# Altium schematic sheet TOP.SchDoc(TOP)
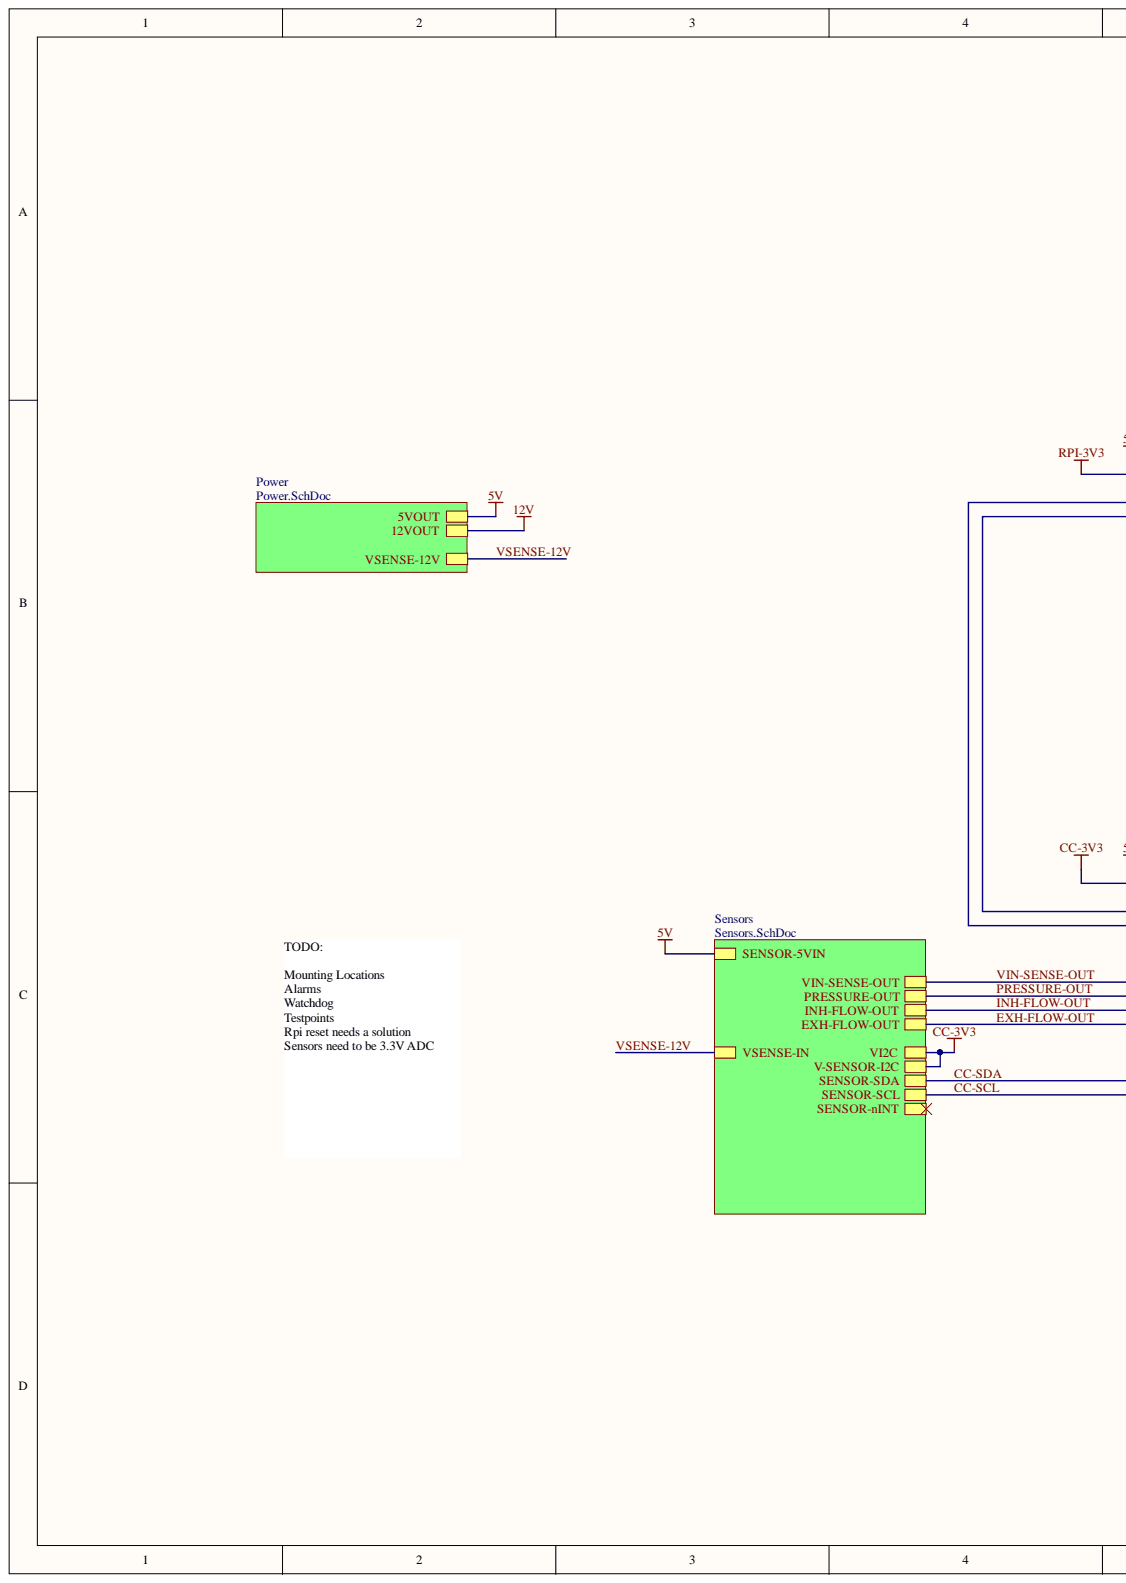
[diagram p0: TOP.SchDoc, left]
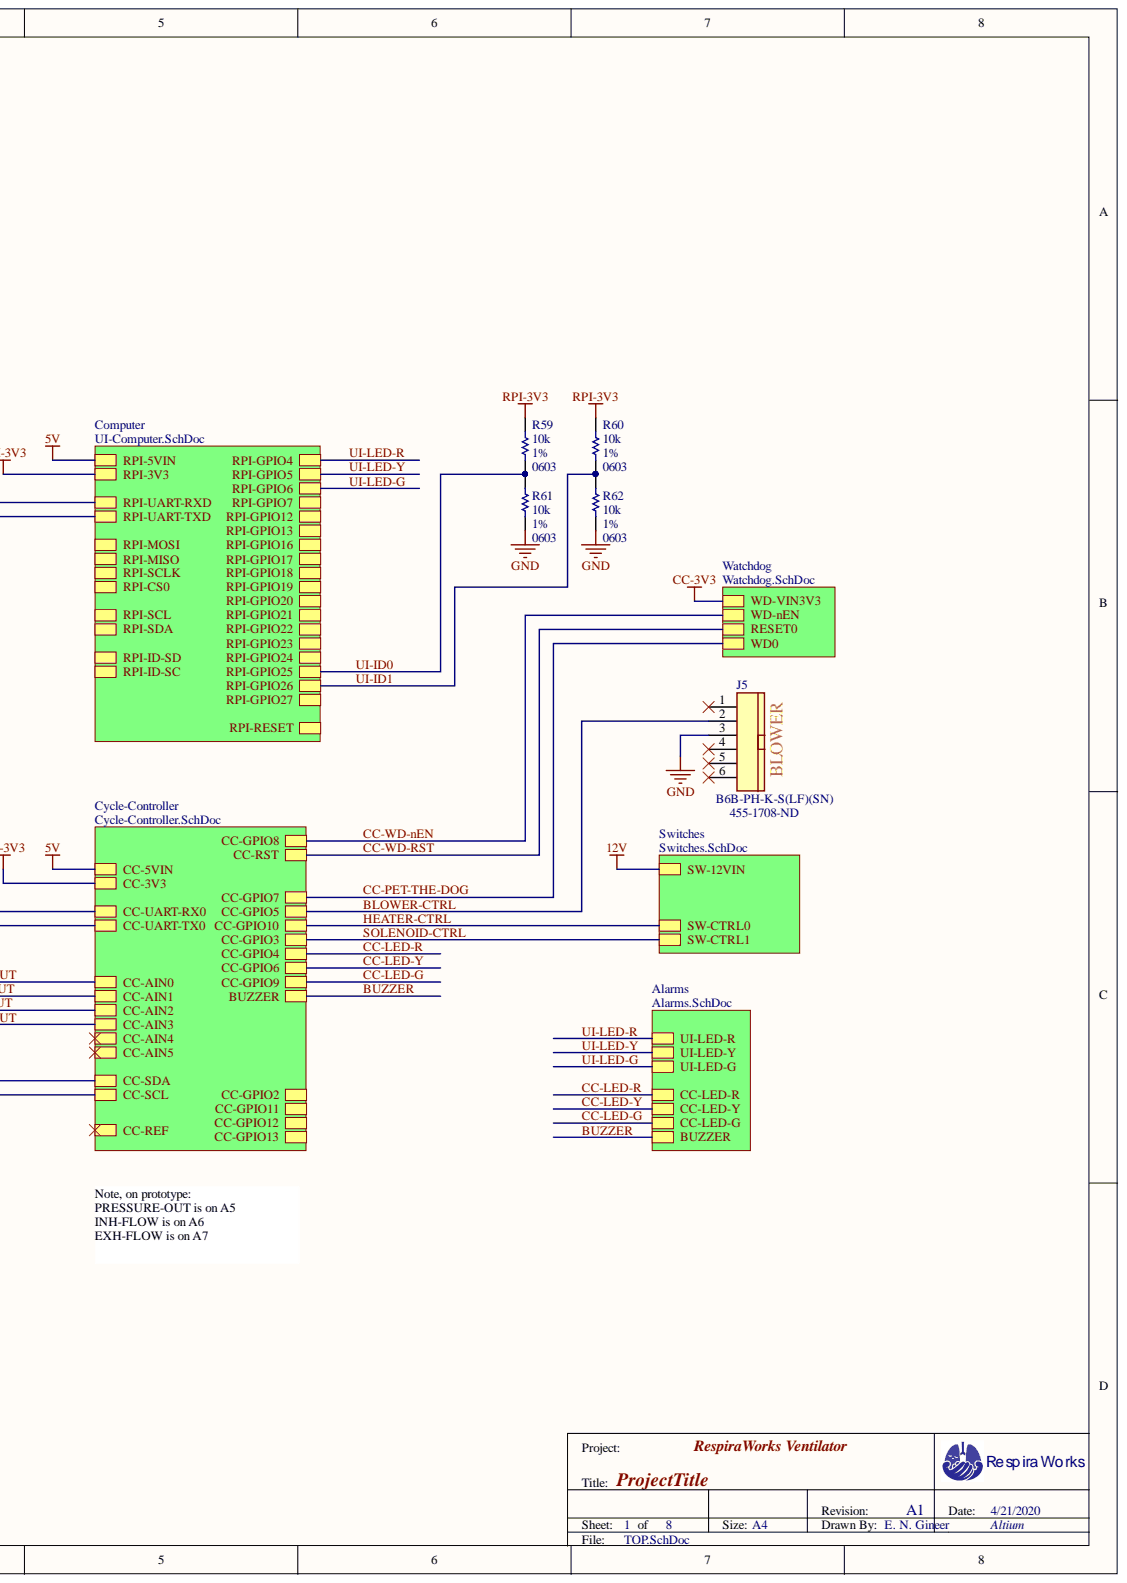
[diagram p1: TOP.SchDoc, right]

## Parts
J5  B6B-PH-K-S(LF)(SN)  Supplier Part Number 1: 455-1708-ND  [JST_B6B-XH-AM]
R59  value 10k  Tolerance: 1%  PCB Package: 0603  [RES0603]  (hidden comment: RES 0603)
R60  value 10k  Tolerance: 1%  PCB Package: 0603  [RES0603]  (hidden comment: RES 0603)
R61  value 10k  Tolerance: 1%  PCB Package: 0603  [RES0603]  (hidden comment: RES 0603)
R62  value 10k  Tolerance: 1%  PCB Package: 0603  [RES0603]  (hidden comment: RES 0603)

## Nets
GND: J5.3 R61.1 R62.1
RPI-3V3: R59.2 R60.2
BLOWER-CTRL: J5.2
UI-ID0: R59.1 R61.2
UI-ID1: R60.1 R62.2
NetJ5_1: J5.1
NetJ5_4: J5.4
NetJ5_5: J5.5
NetJ5_6: J5.6
5V:
12V:
BUZZER:
CC-3V3:
CC-AIN4:
CC-AIN5:
CC-GPIO2:
CC-GPIO11:
CC-GPIO12:
CC-GPIO13:
CC-LED-G:
CC-LED-R:
CC-LED-Y:
CC-PET-THE-DOG:
CC-REF:
CC-SCL:
CC-SDA:
CC-WD-nEN:
CC-WD-RST:
EXH-FLOW-OUT:
HEATER-CTRL:
INH-FLOW-OUT:
PRESSURE-OUT:
RPI-CS0:
RPI-GPIO7:
RPI-GPIO12:
RPI-GPIO13:
RPI-GPIO16:
RPI-GPIO17:
RPI-GPIO18:
RPI-GPIO19:
RPI-GPIO20:
RPI-GPIO21:
RPI-GPIO22:
RPI-GPIO23:
RPI-GPIO24:
RPI-GPIO27:
RPI-ID-SC:
RPI-ID-SD:
RPI-MISO:
RPI-MOSI:
RPI-RESET:
RPI-SCL:
RPI-SCLK:
RPI-SDA:
RPI-UART-RXD:
RPI-UART-TXD:
SENSOR-nINT:
SOLENOID-CTRL:
UI-LED-G:
UI-LED-R:
UI-LED-Y:
VIN-SENSE-OUT:
VSENSE-12V:

## Library data of the parts
J5 = B6B-PH-K-S(LF)(SN): CONN HEADER PH TOP 6POS 2MM; Manufacturer: JST Sales America Inc.; Pitch: 0.079" (2.00mm); Number of Positions Loaded: All; Number of Positions: 6; Connector Type: Header, Shrouded; Termination: Press-Fit, Solder; Supplier 1: Digi-Key; Stock 1: 30111; Row Spacing: -; RoHS: RoHS Compliant; Pricing 1: 1=0.33, 10=0.313, 100=0.2194, 500=0.18808, 1000=0.15674, 5000=0.13435, 10000=0.12539 (USD); Part Status: Active; Packaging: Bulk; Number of Rows: 1; Mounting Type: Through Hole; Manufacturer Part Number: B6B-PH-K-S(LF)(SN); Library Name: JST XH.SchLib; Features: -; Fastening Type: Detent Lock; Contact Type: Male Pin; Contact Mating Length: 0.130" (3.30mm); Contact Finish Thickness: -; Contact Finish: Tin; ComponentLink1URL: http://www.jst-mfg.com/product/pdf/eng/ePH.pdf; ComponentLink1Description: http://www.jst-mfg.com/product/pdf/eng/ePH.pdf; Component Kind: Standard; Color: Natural; Category: Connectors, Interconnects
R59-R62 = RES 0603: Manufacturer P/N: ERJ-3EKF1002V; Manufacturer: Panasonic; Library Name: generic.SchLib; Description: Res 0603; Component Kind: Standard
